# Altium schematic sheet 03_power.SchDoc
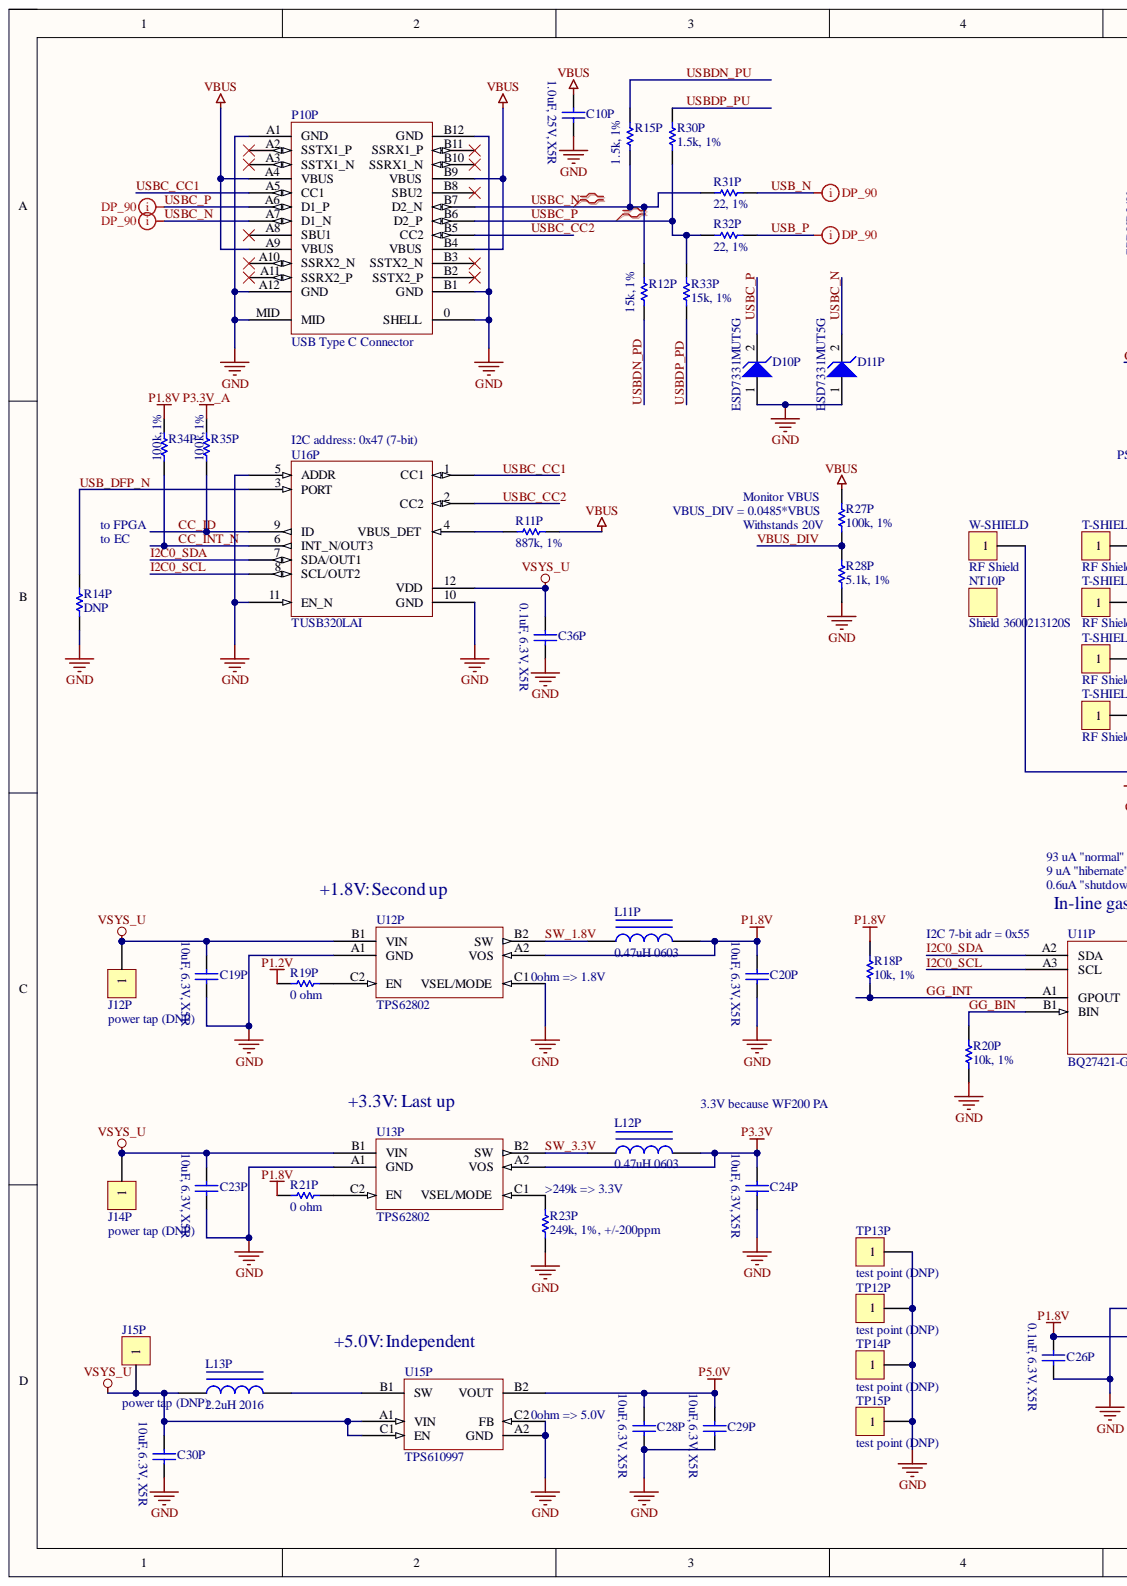
[diagram p0: 03_power.SchDoc, left]
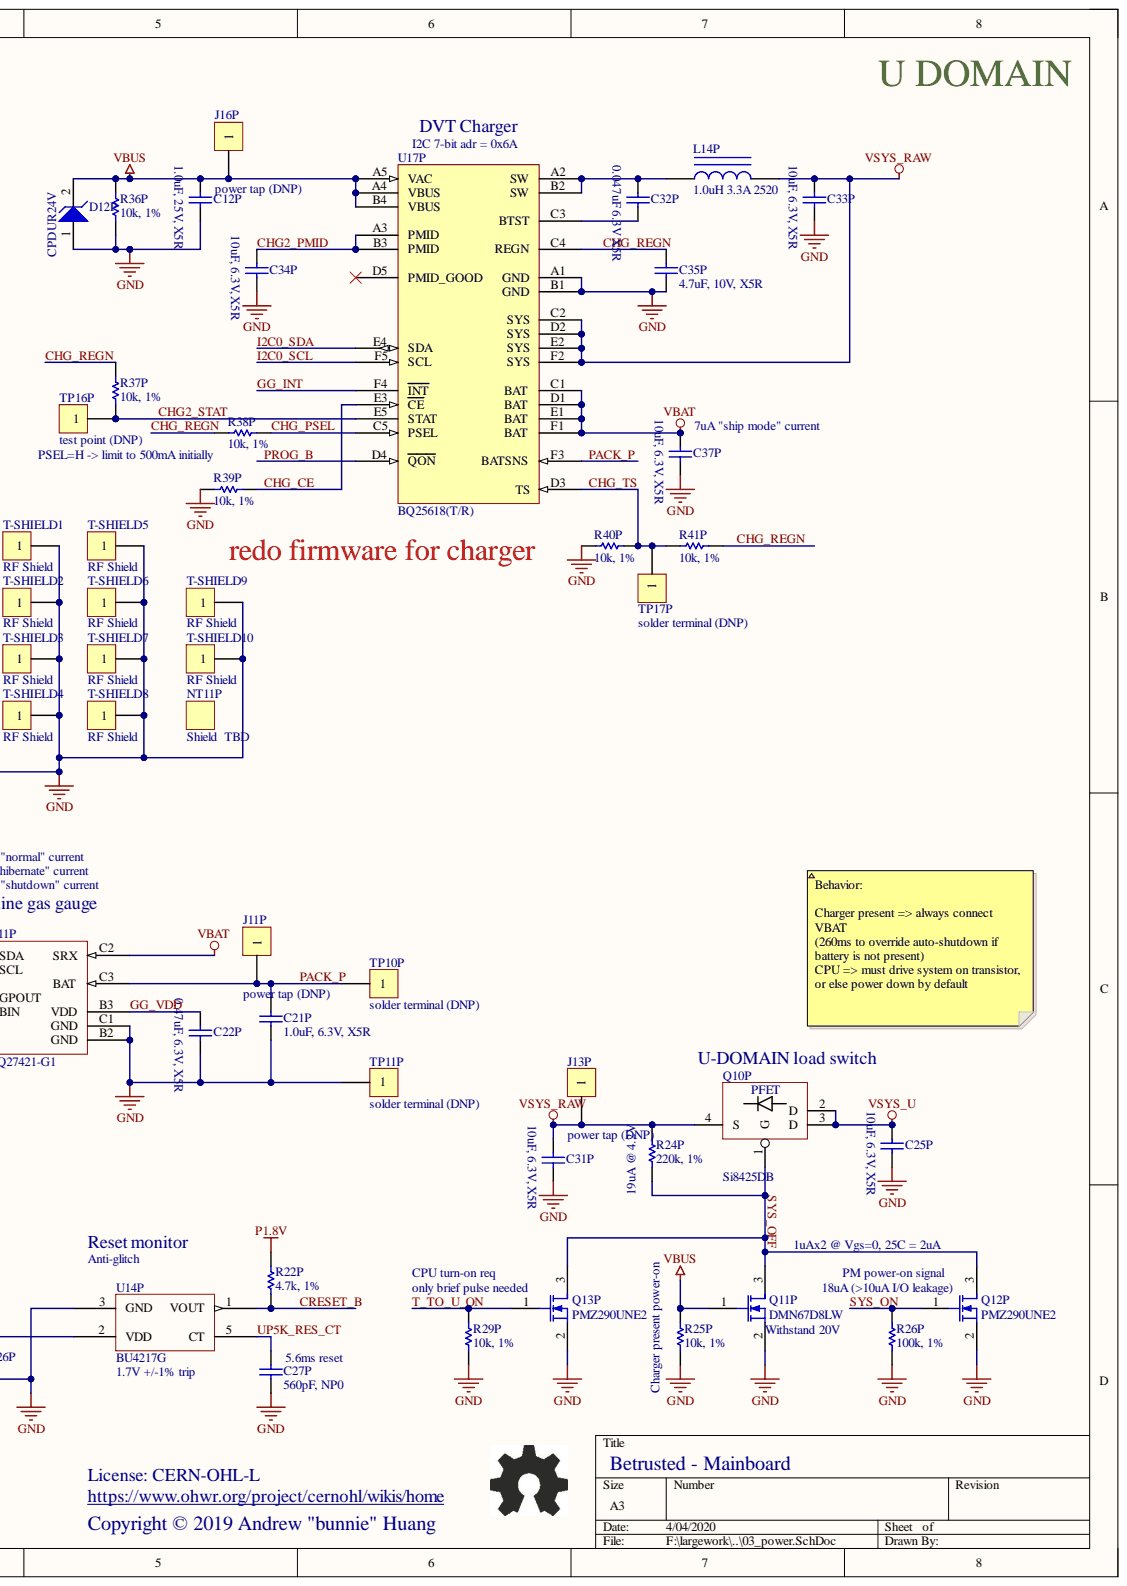
[diagram p1: 03_power.SchDoc, right]

## Parts
C10P  1.0uF, 25V, X5R  [B_CAP0603]
C12P  1.0uF, 25V, X5R  [B_CAP0603]
C19P  10uF, 6.3V, X5R  [B_CAP0603]
C20P  10uF, 6.3V, X5R  [B_CAP0603]
C21P  1.0uF, 6.3V, X5R  [B_CAP0201]
C22P  0.47uF, 6.3V, X5R  [B_CAP0201]
C23P  10uF, 6.3V, X5R  [B_CAP0603]
C24P  10uF, 6.3V, X5R  [B_CAP0603]
C25P  10uF, 6.3V, X5R  [B_CAP0603]
C26P  0.1uF, 6.3V, X5R  [B_CAP0201]
C27P  560pF, NP0  [B_RES0201]
C28P  10uF, 6.3V, X5R  [B_CAP0603]
C29P  10uF, 6.3V, X5R  [B_CAP0603]
C30P  10uF, 6.3V, X5R  [B_CAP0603]
C31P  10uF, 6.3V, X5R  [B_CAP0603]
C32P  0.047uF 6.3V X5R  [B_CAP0201]
C33P  10uF, 6.3V, X5R  [B_CAP0603]
C34P  10uF, 6.3V, X5R  [B_CAP0603]
C35P  4.7uF, 10V, X5R  [B_CAP0603]
C36P  0.1uF, 6.3V, X5R  [B_CAP0201]
C37P  10uF, 6.3V, X5R  [B_CAP0603]
D10P  ESD7331MUT5G  [D_X3DFN2_0201]
D11P  ESD7331MUT5G  [D_X3DFN2_0201]
D12P  CPDUR24V  [SOD523]
J11P  power tap (DNP)  [RESTAP-0603]
J12P  power tap (DNP)  [RESTAP-0603]
J13P  power tap (DNP)  [RESTAP-0603]
J14P  power tap (DNP)  [RESTAP-0603]
J15P  power tap (DNP)  [RESTAP-0603]
J16P  power tap (DNP)  [RESTAP-0603]
L11P  0.47uH 0603  [B_IND0603]
L12P  0.47uH 0603  [B_IND0603]
L13P  2.2uH 2016  [B_IND2016_TDK]
L14P  1.0uH 3.3A 2520  [B_IND2025_SAM]
NT10P  Shield 3600213120S  MPN: 3600213120S
NT11P  Shield  TBD  MPN: TBD
P10P  USB Type C Connector  [TE_1-2295018-2]
Q10P  Si8425DB  [VISHAY_MICRO_FOOT_4_BUMP]
Q11P  DMN67D8LW  [SOT323-3AN]
Q12P  PMZ290UNE2  [SOT883]
Q13P  PMZ290UNE2  [SOT883]
R11P  887k, 1%  [B_RES0201]
R12P  15k, 1%  [B_RES0201]
R14P  DNP  [B_RES0201]
R15P  1.5k, 1%  [B_RES0201]
R18P  10k, 1%  [B_RES0201]
R19P  0 ohm  [B_RES0201]
R20P  10k, 1%  [B_RES0201]
R21P  0 ohm  [B_RES0201]
R22P  4.7k, 1%  [B_RES0201]
R23P  249k, 1%, +/-200ppm  [B_RES0402]
R24P  220k, 1%  [B_RES0201]
R25P  10k, 1%  [B_RES0201]
R26P  100k, 1%  [B_RES0201]
R27P  100k, 1%  [B_RES0201]
R28P  5.1k, 1%  [B_RES0201]
R29P  10k, 1%  [B_RES0201]
R30P  1.5k, 1%  [B_RES0201]
R31P  22, 1%  [B_RES0201]
R32P  22, 1%  [B_RES0201]
R33P  15k, 1%  [B_RES0201]
R34P  100k, 1%  [B_RES0201]
R35P  100k, 1%  [B_RES0201]
R36P  10k, 1%  [B_RES0201]
R37P  10k, 1%  [B_RES0201]
R38P  10k, 1%  [B_RES0201]
R39P  10k, 1%  [B_RES0201]
R40P  10k, 1%  [B_RES0201]
R41P  10k, 1%  [B_RES0201]
T-SHIELD1  RF Shield  [WURTH_36900000]
T-SHIELD2  RF Shield  [WURTH_36900000]
T-SHIELD3  RF Shield  [WURTH_36900000]
T-SHIELD4  RF Shield  [WURTH_36900000]
T-SHIELD5  RF Shield  [WURTH_36900000]
T-SHIELD6  RF Shield  [WURTH_36900000]
T-SHIELD7  RF Shield  [WURTH_36900000]
T-SHIELD8  RF Shield  [WURTH_36900000]
T-SHIELD9  RF Shield  [WURTH_36900000]
T-SHIELD10  RF Shield  [WURTH_36900000]
TP10P  solder terminal (DNP)  [55-MIL-HOLE]
TP11P  solder terminal (DNP)  [55-MIL-HOLE]
TP12P  test point (DNP)  [TP_2MM_SQ]
TP13P  test point (DNP)  [TP_2MM_SQ]
TP14P  test point (DNP)  [TP_2MM_SQ]
TP15P  test point (DNP)  [TP_2MM_SQ]
TP16P  test point (DNP)  [TP_2MM_SQ]
TP17P  solder terminal (DNP)  [55-MIL-HOLE]
U11P  BQ27421-G1  [BGA9C50P3X3_162X158X62]
U12P  TPS62802  [BGA6C35P2X3_70X105X40_NSMD]
U13P  TPS62802  [BGA6C35P2X3_70X105X40_NSMD]
U14P  BU4217G  [SOT23-5AN]
U15P  TPS610997  [BGA6C40P2X3_88X123X62]
U16P  TUSB320LAI  [QFN40P160X160X40-12N]
U17P  BQ25618(T/R)  [BGA30C40P5X6_196X236X62]
W-SHIELD  RF Shield  [WURTH_36X021312XS]

## Nets
GND: C10P.2 C12P.2 C19P.2 C20P.2 C21P.1 C22P.2 C23P.2 C24P.2 C25P.2 C26P.2 C27P.2 C28P.2 C29P.2 C30P.2 C31P.2 C33P.2 C34P.2 C35P.1 C36P.2 C37P.2 D10P.1 D11P.1 D12P.1 P10P.0(SHELL) P10P.A1(GND) P10P.A12(GND) P10P.B1(GND) P10P.B12(GND) P10P.MID Q11P.2 Q12P.2 Q13P.2 R14P.2 R20P.2 R23P.2 R25P.2 R26P.1 R28P.2 R29P.2 R36P.1 R39P.1 R40P.1 T-SHIELD1.1 T-SHIELD2.1 T-SHIELD3.1 T-SHIELD4.1 T-SHIELD5.1 T-SHIELD6.1 T-SHIELD7.1 T-SHIELD8.1 T-SHIELD9.1 T-SHIELD10.1 TP11P.1 TP12P.1 TP13P.1 TP14P.1 TP15P.1 U11P.B2(GND) U11P.C1(GND) U12P.A1(GND) U12P.C1(VSEL/MODE) U13P.A1(GND) U14P.3(GND) U15P.A2(GND) U15P.C2(FB) U16P.5(ADDR) U16P.10(GND) U16P.11(EN_N) U17P.A1(GND) U17P.B1(GND) W-SHIELD.1
P1.2V: R19P.1
P1.8V: C20P.1 C26P.1 L11P.2 R18P.1 R21P.1 R22P.2 R34P.2 U12P.A2(VOS) U14P.2(VDD)
P3.3V: C24P.1 L12P.2 U13P.A2(VOS)
P3.3V_A: R35P.2
P5.0V: C28P.1 C29P.1 U15P.B2(VOUT)
VBAT: C37P.1 U11P.C2(SRX) U17P.C1(BAT) U17P.D1(BAT) U17P.E1(BAT) U17P.F1(BAT)
VBUS: C10P.1 C12P.1 D12P.2 J16P.1 P10P.A4(VBUS) P10P.A9(VBUS) P10P.B4(VBUS) P10P.B9(VBUS) Q11P.1 R11P.1 R25P.1 R27P.2 R36P.2 U17P.A4(VBUS) U17P.A5(VAC) U17P.B4(VBUS)
VSYS_RAW: C31P.1 C33P.1 J13P.1 L14P.2 Q10P.4(S) R24P.2 U17P.C2(SYS) U17P.D2(SYS) U17P.E2(SYS) U17P.F2(SYS)
VSYS_U: C19P.1 C23P.1 C25P.1 C30P.1 C36P.1 J12P.1 J14P.1 J15P.1 L13P.1 Q10P.2(D) Q10P.3(D) U12P.B1(VIN) U13P.B1(VIN) U15P.A1(VIN) U15P.C1(EN) U16P.12(VDD)
CC_ID: R35P.1 U16P.9(ID)
CC_INT_N: R34P.1 U16P.6(INT_N/OUT3)
CHG2_PMID: C34P.1 U17P.A3(PMID) U17P.B3(PMID)
CHG2_STAT: R37P.2 TP16P.1 U17P.E5(STAT)
CHG_CE: R39P.2 U17P.E3(CE)
CHG_PSEL: R38P.2 U17P.C5(PSEL)
CHG_REGN: C35P.2 R37P.1 R38P.1 R41P.2 U17P.C4(REGN)
CHG_TS: R40P.2 R41P.1 TP17P.1 U17P.D3(TS)
CRESET_B: R22P.1 U14P.1(VOUT)
GG_BIN: R20P.1 U11P.B1(BIN)
GG_INT: R18P.2 U11P.A1(GPOUT) U17P.F4(INT)
GG_VDD: C22P.1 U11P.B3(VDD)
I2C0_SCL: U11P.A3(SCL) U16P.8(SCL/OUT2) U17P.F5(SCL)
I2C0_SDA: U11P.A2(SDA) U16P.7(SDA/OUT1) U17P.E4(SDA)
PACK_P: C21P.2 J11P.1 TP10P.1 U11P.C3(BAT) U17P.F3(BATSNS)
PROG_B: U17P.D4(QON)
SW_1.8V: L11P.1 U12P.B2(SW)
SW_3.3V: L12P.1 U13P.B2(SW)
SYS_OFF: Q10P.1(G) Q11P.3 Q12P.3 Q13P.3 R24P.1
SYS_ON: Q12P.1 R26P.2
T_TO_U_ON: Q13P.1 R29P.1
UP5K_RES_CT: C27P.1 U14P.5(CT)
USB_DFP_N: R14P.1 U16P.3(PORT)
USB_N: R31P.2
USB_P: R32P.2
USBC_CC1: P10P.A5(CC1) U16P.1(CC1)
USBC_CC2: P10P.B5(CC2) U16P.2(CC2)
USBC_N: D11P.2 P10P.A7(D1_N) P10P.B7(D2_N) R12P.1 R15P.1 R31P.1
USBC_P: D10P.2 P10P.A6(D1_P) P10P.B6(D2_P) R30P.1 R32P.1 R33P.1
USBDN_PD: R12P.2
USBDN_PU: R15P.2
USBDP_PD: R33P.2
USBDP_PU: R30P.2
VBUS_DIV: R27P.1 R28P.1
NetC32P_1: C32P.1 L14P.1 U17P.A2(SW) U17P.B2(SW)
NetC32P_2: C32P.2 U17P.C3(BTST)
NetL13P_2: L13P.2 U15P.B1(SW)
NetR11P_2: R11P.2 U16P.4(VBUS_DET)
NetR19P_2: R19P.2 U12P.C2(EN)
NetR21P_2: R21P.2 U13P.C2(EN)
NetR23P_1: R23P.1 U13P.C1(VSEL/MODE)

Not connected: P10P A2(SSTX1_P) A3(SSTX1_N) A8(SBU1) A10(SSRX2_N) A11(SSRX2_P) B2(SSTX2_P) B3(SSTX2_N) B8(SBU2) B10(SSRX1_N) B11(SSRX1_P); U17P D5(PMID_GOOD)

## Library data of the parts
C10P C12P = Cap Semi: Capacitor (Semiconductor SIM Model); MPN: CL10A105KA8NNNC; MYPN: C-105-25-X5R-0603; VENDOR: Samsung; Component Kind: Standard; Library Name: Miscellaneous Devices.IntLib; Signal Integrity: Cap Semi; Simulation: CAP
C19P C20P C23P C24P C25P C28P C29P C30P C31P C33P C34P C37P = Cap Semi: Capacitor (Semiconductor SIM Model); MPN: CL10A106MQ8NNNC; MYPN: C-106-6.3-X5R-0603; VENDOR: Samsung; Component Kind: Standard; Library Name: Miscellaneous Devices.IntLib; Signal Integrity: Cap Semi; Simulation: CAP
C21P = Cap Semi: Capacitor (Semiconductor SIM Model); MPN: GRM033R60J105MEA2D; MYPN: C-105-6p3-X5R-0201; VENDOR: Murata; Component Kind: Standard; Library Name: Miscellaneous Devices.IntLib; Signal Integrity: Cap Semi; Simulation: CAP
C22P = Cap Semi: Capacitor (Semiconductor SIM Model); MPN: CL03A474KQ3NNNH; MYPN: C-474-6p3-X5R-0201; VENDOR: Samsung; Component Kind: Standard; Library Name: Miscellaneous Devices.IntLib; Signal Integrity: Cap Semi; Simulation: CAP
C26P C36P = Cap Semi: Capacitor (Semiconductor SIM Model); MPN: CL03A104KQ3NNNC; MYPN: C-104-6p3-X5R-0201; VENDOR: Samsung; Component Kind: Standard; Library Name: Miscellaneous Devices.IntLib; Signal Integrity: Cap Semi; Simulation: CAP
C27P = Cap Semi: Capacitor (Semiconductor SIM Model); MPN: GRM0335C1E561JA01D; MYPN: C-561-25-NP0-0201; VENDOR: Murata; Component Kind: Standard; Library Name: Miscellaneous Devices.IntLib; Signal Integrity: Cap Semi; Simulation: CAP
C32P = Cap Semi: Capacitor (Semiconductor SIM Model); MPN: GRM033C81A473KE05D; MYPN: C-473-10-X6S-0201; VENDOR: Murata; Component Kind: Standard; Library Name: Miscellaneous Devices.IntLib; Signal Integrity: Cap Semi; Simulation: CAP
C35P = Cap Semi: Capacitor (Semiconductor SIM Model); MPN: CL10A475KP8NNNC; MYPN: C-475-10-X5R-0603; VENDOR: Samsung; Component Kind: Standard; Library Name: Miscellaneous Devices.IntLib; Signal Integrity: Cap Semi; Simulation: CAP
D10P D11P = D Zener: MYPN: D-ESD7331MUT5G; VENDOR: ON Semi; Component Kind: Standard; Description: ESD7331MUT5G; LatestRevisionDate: 17-Jul-2002; LatestRevisionNote: Re-released for DXP Platform.; Library Name: Miscellaneous Devices.IntLib; MPN: ESD7331MUT5G; PackageDescription: Diode, Thru-Hole; 2 Leads; 0.7 in Pin Spacing; PackageReference: DIODE-0.7; Published: 8-Jun-2000; Signal Integrity: D Zener; Simulation: ZENER; Publisher: Altium Limited
D12P = D Zener: MYPN: D-CPDUR24V; VENDOR: Comchip Technology; Component Kind: Standard; Description: CPDUR24V; LatestRevisionDate: 17-Jul-2002; LatestRevisionNote: Re-released for DXP Platform.; Library Name: Miscellaneous Devices.IntLib; MPN: CPDUR24V; PackageDescription: Diode, Thru-Hole; 2 Leads; 0.7 in Pin Spacing; PackageReference: DIODE-0.7; Published: 8-Jun-2000; Signal Integrity: D Zener; Simulation: ZENER; Publisher: Altium Limited
J11P J12P J13P J14P J15P J16P = CON1: Connector; MYPN: J-TP-PWRTAP-0603; Component Kind: Standard; MPN: DNP; VENDOR: DNP
L11P L12P = Inductor Iron: Magnetic-Core Inductor; MPN: TFM160808ALC-R47MTAA; MYPN: L-TFM160808ALC-R47MTAA; VENDOR: TDK; Component Kind: Standard; Library Name: Miscellaneous Devices.IntLib; Signal Integrity: Inductor Iron; Simulation: INDUCTOR
L13P = Inductor Iron: Magnetic-Core Inductor; MPN: VLS201610CX-2R2M-1; MYPN: L-VLS201610CX-2R2M-1; VENDOR: TDK; Component Kind: Standard; Library Name: Miscellaneous Devices.IntLib; Signal Integrity: Inductor Iron; Simulation: INDUCTOR
L14P = Inductor Iron: Magnetic-Core Inductor; MPN: CIGW252010GL1R0MNE; MYPN: L-CIGW252010GL1R0MNE; VENDOR: Samsung; Component Kind: Standard; Library Name: Miscellaneous Devices.IntLib; Signal Integrity: Inductor Iron; Simulation: INDUCTOR
NT10P = NOTE: RF Shield snap-on; MYPN: NT-3600213120S; VENDOR: Wurth; Component Kind: Standard; Library Name: commonsch.lib
NT11P = NOTE: RF Shield snap-on; VENDOR: Placeholder; Component Kind: Standard; Library Name: commonsch.lib; MYPN: TBD
P10P = USB_TYPE_C: MPN: 1-2295018-2; MYPN: P-1-2295018-2; VENDOR: TE Connectivity AMP Connectors; Component Kind: Standard; Description: USB Type C Connector; Library Name: commonsch-new.SchLib
Q10P = Si8425DB: P-channel 20V 6.2A 27mOhm @ -2.5V; MPN: SI8457DB-T1-E1; MYPN: Q-Si8457; VENDOR: Vishay Siliconix; Component Kind: Standard; Library Name: temp-to-merge.SchLib
Q11P = MOSFET-N: N-Channel MOSFET; MYPN: Q-DMN67D8LW; VENDOR: Diodes Inc; Component Kind: Standard; LatestRevisionDate: 17-Jul-2002; LatestRevisionNote: Re-released for DXP Platform.; Library Name: Miscellaneous Devices.IntLib; MPN: DMN67D8LW; PackageReference: E3; PackageVersion: 1995/1996; Published: 8-Jun-2000; Simulation: NMOS; Publisher: Altium Limited
Q12P Q13P = MOSFET-N: N-Channel MOSFET; MYPN: Q-PMZ290UNE2; VENDOR: Nexperia; Component Kind: Standard; LatestRevisionDate: 17-Jul-2002; LatestRevisionNote: Re-released for DXP Platform.; Library Name: Miscellaneous Devices.IntLib; MPN: PMZ290UNE2; PackageReference: E3; PackageVersion: 1995/1996; Published: 8-Jun-2000; Simulation: NMOS; Publisher: Altium Limited
R11P = Res1: Resistor; MPN: ANY; MYPN: R-8873-1P-1_16W-0201; Component Kind: Standard; Library Name: Miscellaneous Devices.IntLib; Signal Integrity: Res; Simulation: RESISTOR; VENDOR: ANY
R12P R33P = Res1: Resistor; MPN: ANY; MYPN: R-153-1P-1_16W-0201; Component Kind: Standard; Library Name: Miscellaneous Devices.IntLib; Signal Integrity: Res; Simulation: RESISTOR; VENDOR: ANY
R14P = Res1: Resistor; MPN: ANY; Component Kind: Standard; Library Name: Miscellaneous Devices.IntLib; MYPN: DNP; Signal Integrity: Res; Simulation: RESISTOR; VENDOR: ANY
R15P R30P = Res1: Resistor; MPN: ANY; MYPN: R-152-1P-1_16W-0201; Component Kind: Standard; Library Name: Miscellaneous Devices.IntLib; Signal Integrity: Res; Simulation: RESISTOR; VENDOR: ANY
R18P R20P R25P R29P R36P R37P R38P R39P R40P R41P = Res1: Resistor; MPN: ANY; MYPN: R-103-1P-1_16W-0201; Component Kind: Standard; Library Name: Miscellaneous Devices.IntLib; Signal Integrity: Res; Simulation: RESISTOR; VENDOR: ANY
R19P R21P = Res1: Resistor; MPN: ANY; MYPN: R-0R0-1P-1_16W-0201; Component Kind: Standard; Library Name: Miscellaneous Devices.IntLib; Signal Integrity: Res; Simulation: RESISTOR; VENDOR: ANY
R22P = Res1: Resistor; MPN: ANY; MYPN: R-472-1P-1_16W-0201; Component Kind: Standard; Library Name: Miscellaneous Devices.IntLib; Signal Integrity: Res; Simulation: RESISTOR; VENDOR: ANY
R23P = Res1: Resistor; MPN: RC0402FR-07249KL; MYPN: R-2493-1P-1_16W-0402; VENDOR: Yageo; Component Kind: Standard; Library Name: Miscellaneous Devices.IntLib; Signal Integrity: Res; Simulation: RESISTOR
R24P = Res1: Resistor; MPN: ANY; MYPN: R-224-1P-1_16W-0201; Component Kind: Standard; Library Name: Miscellaneous Devices.IntLib; Signal Integrity: Res; Simulation: RESISTOR; VENDOR: ANY
R26P R27P R34P R35P = Res1: Resistor; MPN: ANY; MYPN: R-104-1P-1_16W-0201; Component Kind: Standard; Library Name: Miscellaneous Devices.IntLib; Signal Integrity: Res; Simulation: RESISTOR; VENDOR: ANY
R28P = Res1: Resistor; MPN: ANY; MYPN: R-512-1P-1_16W-0201; Component Kind: Standard; Library Name: Miscellaneous Devices.IntLib; Signal Integrity: Res; Simulation: RESISTOR; VENDOR: ANY
R31P R32P = Res1: Resistor; MPN: ANY; MYPN: R-220-1P-1_16W-0201; Component Kind: Standard; Library Name: Miscellaneous Devices.IntLib; Signal Integrity: Res; Simulation: RESISTOR; VENDOR: ANY
T-SHIELD1-T-SHIELD10 = CON1: Connector; MPN: 36900000; MYPN: J-36900000; VENDOR: Wurth; Component Kind: Standard
TP10P TP11P TP12P TP13P TP14P TP15P TP16P TP17P = CON1: Connector; MYPN: Built into PCB; Component Kind: Standard; MPN: DNP; VENDOR: DNP
U11P = BQ27421-G1: System-Side Impedance Track Fuel Gauge with integrated Sense Resistor; MPN: bq27421YZFT-G1A; MYPN: bq27421YZF(T/R)-G1A; VENDOR: TI; Component Kind: Standard
U12P U13P = TPS62802: Buck regulator, 4MHz, 0.6A, 1.8V-3.3V, low Iq; MPN: TPS62802YKAR; MYPN: U-TPS62802YKA(T/R); VENDOR: TI; Component Kind: Standard
U14P = BU42XXG: Low voltage free delay time setting CMOS voltage detector IC; MPN: BU4217G-TR; MYPN: U-BU4217G-TR; VENDOR: Rohm; Component Kind: Standard; Library Name: commonsch-new.SchLib
U15P = TPS610997: Synchronous Boost Converter with Ultra-Low Iq; MPN: TPS610997YFFR; MYPN: TPS610997YFFT(T/R); VENDOR: TI; Component Kind: Standard
U16P = TUSB320LAI: USB Type-C Configuration Channel Logic and Port Control; MYPN: U-TUSB320LAI; VENDOR: TI; Component Kind: Standard; Library Name: commonsch-new.SchLib; MPN: TUSB320LAI
U17P = BQ25618: I2C controlled 1-cell 1.5A battery charger with 20mA termination and 1A boost operation; MPN: BQ25618YFFT; MYPN: U-BQ25618YFF(T/R); VENDOR: TI; Component Kind: Standard; Library Name: commonsch-new.SchLib
W-SHIELD = CON1: Connector; MPN: 3610213126S; VENDOR: Wurth; Component Kind: Standard; MYPN: 3610213126S
